# Altium schematic sheet Sensors.SchDoc
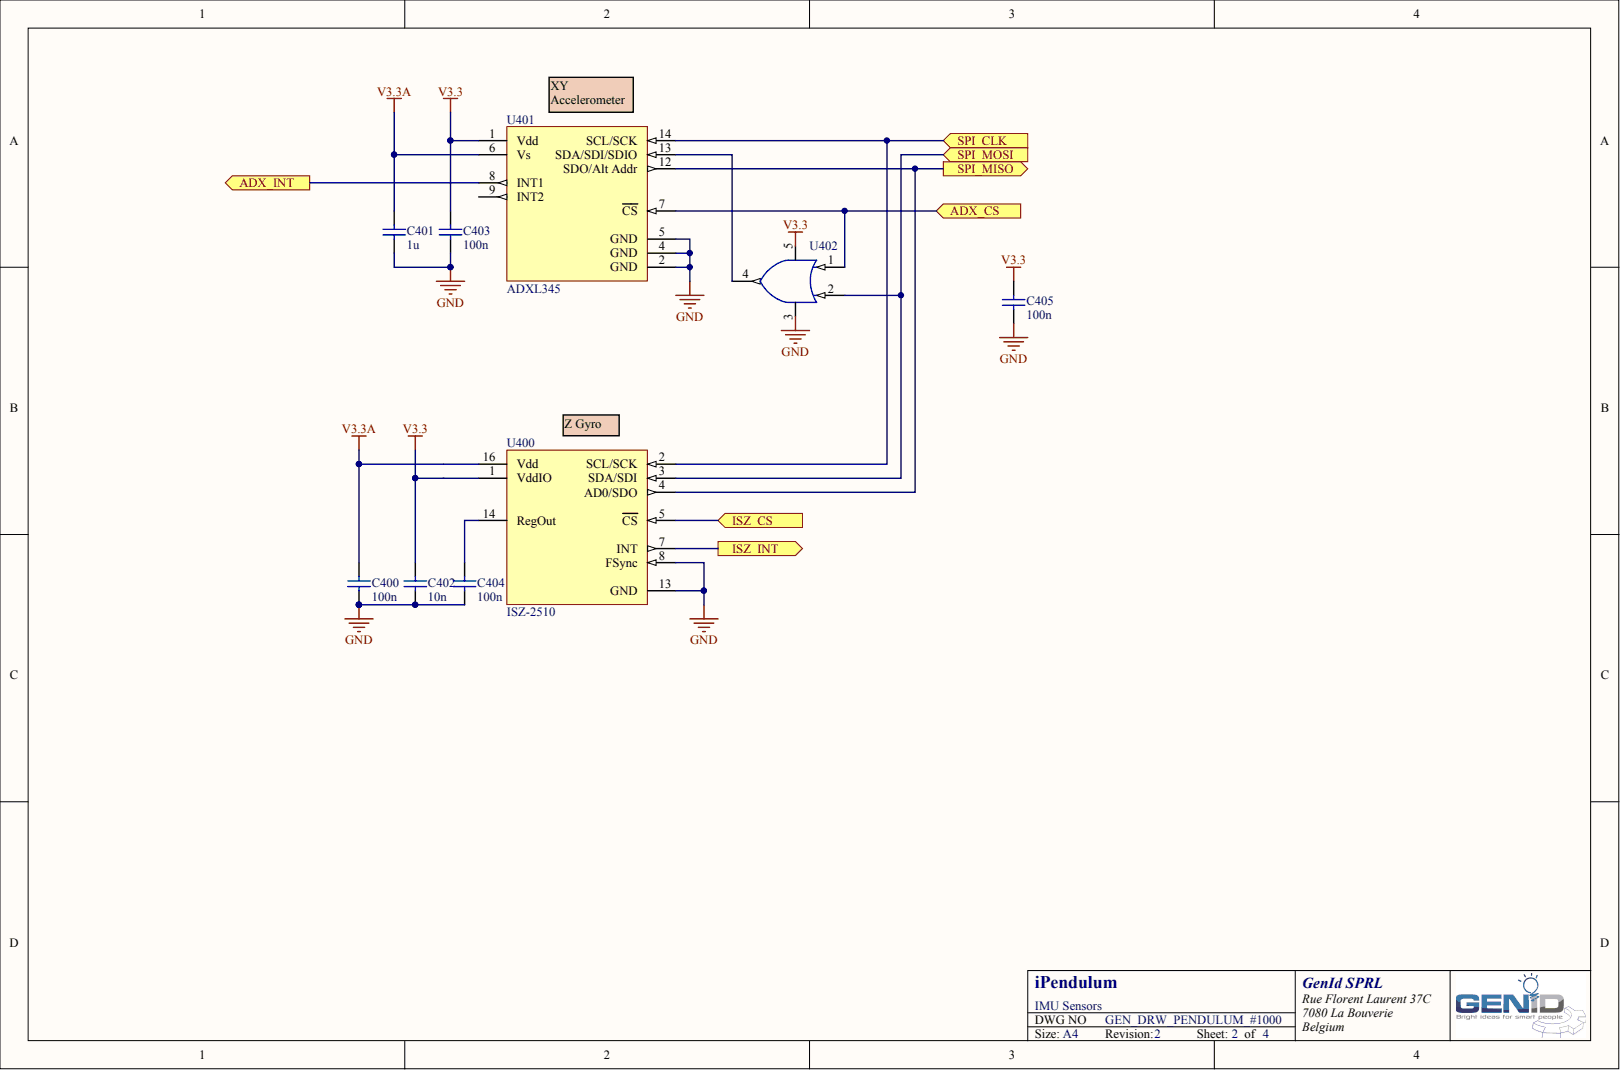
[diagram p0: Sensors.SchDoc]

## Parts
C400  value 100n  [0805-CAP]
C401  value 1u  [0805-CAP]
C402  value 10n  [0805-CAP]
C403  value 100n  [0805-CAP]
C404  value 100n  [0805-CAP]
C405  value 100n  [0805-CAP]
U400  (no value)  [QFN-16]
U401  (no value)  [LGA-14]
U402  (no value)  [DBV]

## Ports
ADX_CS, ADX_INT, ISZ_CS, ISZ_INT, SPI_CLK, SPI_MISO, SPI_MOSI

## Nets
GND: C400.2 C401.2 C402.2 C403.2 C404.2 C405.2 U400.6 U400.8(FSync) U400.13(GND) U400.15 U401.2(GND) U401.4(GND) U401.5(GND) U402.3
V3.3: C402.1 C403.1 C405.1 U400.1(VddIO) U401.1(Vdd) U402.5
V3.3A: C400.1 C401.1 U400.16(Vdd) U401.6(Vs)
ADX_CS: U401.7(CS) U402.1 ; port ADX_CS
ADX_INT: U401.8(INT1) ; port ADX_INT
ISZ_CS: U400.5(CS) ; port ISZ_CS
ISZ_INT: U400.7(INT) ; port ISZ_INT
SPI_CLK: U400.2(SCL/SCK) U401.14(SCL/SCK) ; port SPI_CLK
SPI_MISO: U400.4(AD0/SDO) U401.12(SDO/Alt_Addr) ; port SPI_MISO
SPI_MOSI: U400.3(SDA/SDI) U402.2 ; port SPI_MOSI
NetC404_1: C404.1 U400.14(RegOut)
NetU401_13: U401.13(SDA/SDI/SDIO) U402.4

Not connected: U401 9(INT2)

## Library data of the parts
C400 C403-C405 = C0805C104K8RA: 100n-0805-10V-10%-X7R; Manufacturer: Kemet; Dielectric: X7R; Tolerance: 10%; Voltage: 10V; Component Kind: Standard; HelpURL: http://www.kemet.com/kemet/web/homepage/kechome.nsf/weben/DC9E4F3CDBEF8272852579290047C2CA/$file/KEM_CC101_COMM_SMD.pdf; Library Name: libCapacitors.IntLib; Reference: C0805C104K8RA
C401 = C0805C105K4RA: 1u-0805-16V-10%-X7R; Manufacturer: Kemet; Dielectric: X7R; Tolerance: 10%; Voltage: 16V; Component Kind: Standard; HelpURL: http://www.kemet.com/kemet/web/homepage/kechome.nsf/weben/DC9E4F3CDBEF8272852579290047C2CA/$file/KEM_CC101_COMM_SMD.pdf; Library Name: libCapacitors.IntLib; Reference: C0805C105K4RA
C402 = C0805C103K5RA: 10n-0805-50V-10%-X7R; Manufacturer: Kemet; Dielectric: X7R; Tolerance: 10%; Voltage: 50V; Component Kind: Standard; HelpURL: http://www.kemet.com/kemet/web/homepage/kechome.nsf/weben/DC9E4F3CDBEF8272852579290047C2CA/$file/KEM_CC101_COMM_SMD.pdf; Library Name: libCapacitors.IntLib; Reference: C0805C103K5RA
U400 = ISZ-2510: Single-chip, digital output, single axis MEMS gyroscope; Manufacturer: InvenSense; Component Kind: Standard; HelpURL: http://www.invensense.com/mems/gyro/documents/PS-ISZ-2510A-00.pdf; Library Name: libSensors.IntLib; Reference: ISZ-2510
U401 = ADXL345: 3-Axis, ±2 g/±4 g/±8 g/±16 g Digital Accelerometer; Manufacturer: Analog Devices; Component Kind: Standard; HelpURL: http://www.analog.com/static/imported-files/data_sheets/ADXL345.pdf; Library Name: libSensors.IntLib; Reference: ADXL345
U402 = SN74AHC1G32: Single 2 input Positive Or Gate; Manufacturer: Texas Instrument; Vcc: [2V - 5.5V]; Component Kind: Standard; ComponentLink1Description: Manufacturer Link; ComponentLink1URL: http://www.ti.com/product/SN74AHC1G32?keyMatch=sn74ahc1g32.&tisearch=Search-EN; ComponentLink2Description: Datasheet; ComponentLink2URL: http://www.ti.com/lit/ds/symlink/sn74ahc1g32.pdf; Library Name: libIC-Logic.IntLib; Reference: SN74AHC1G32
